# Altium schematic sheet EP40_SEAT.SchDoc
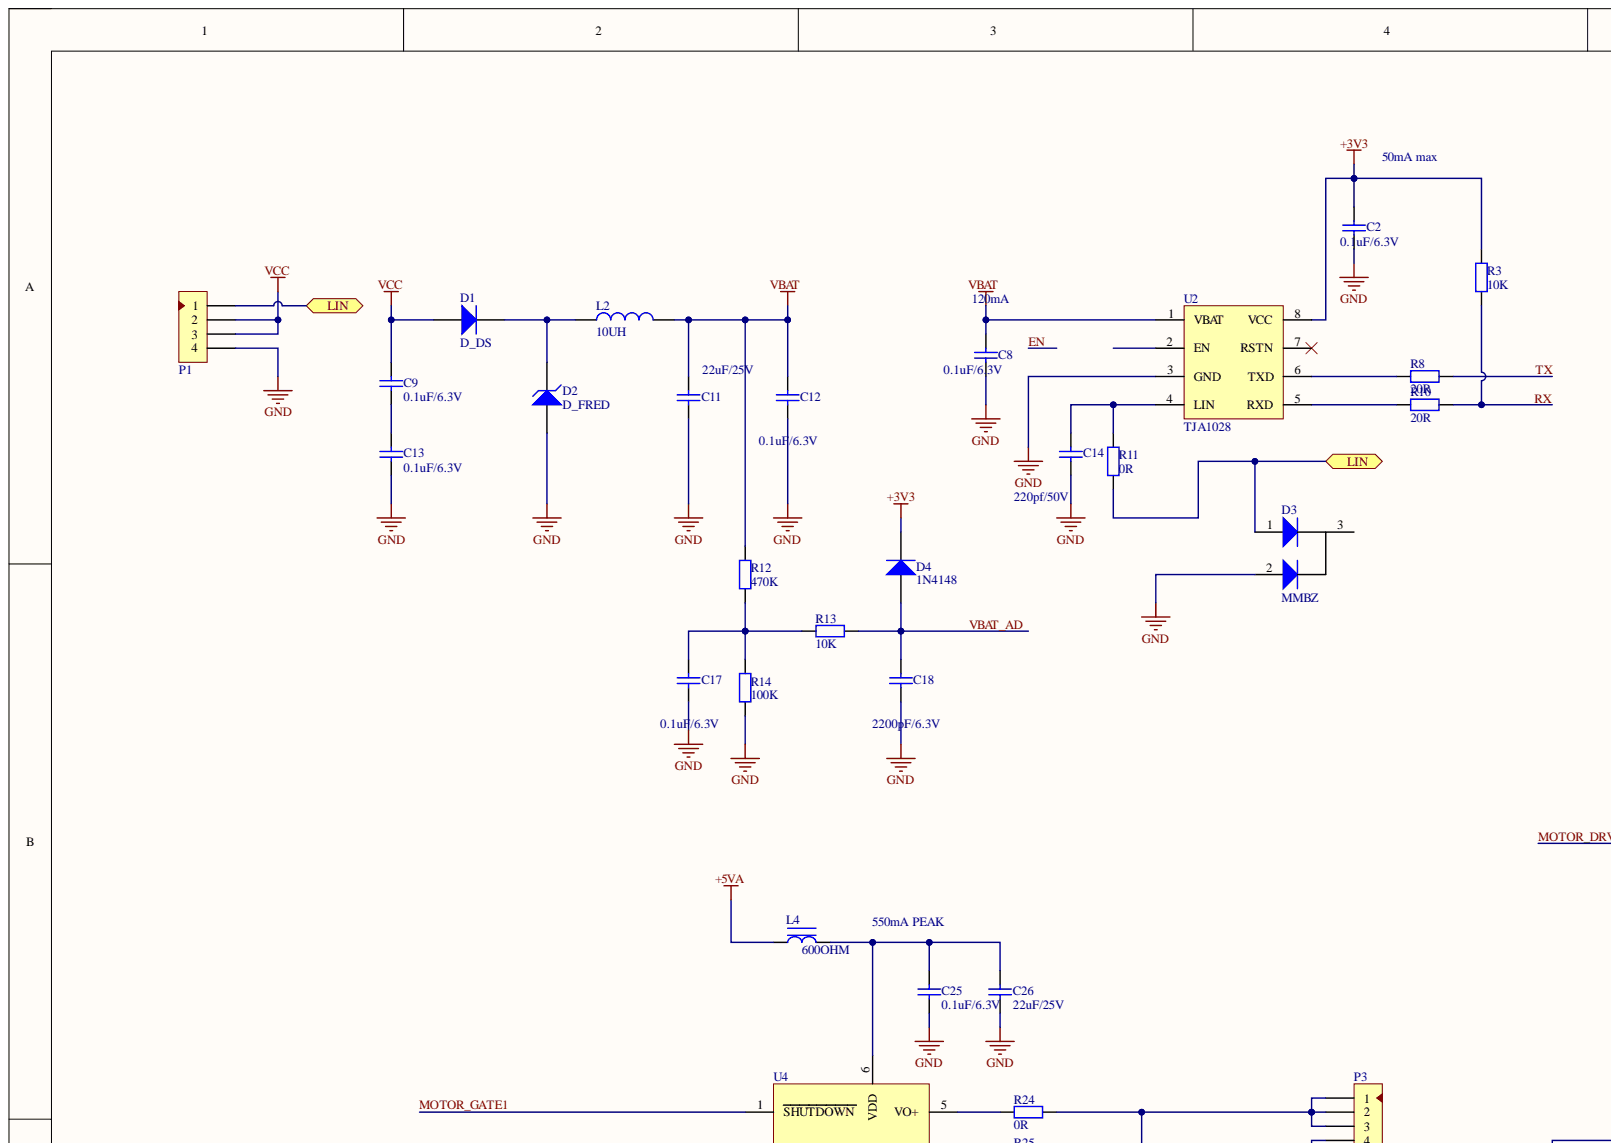
[diagram p0: EP40_SEAT.SchDoc, top left]
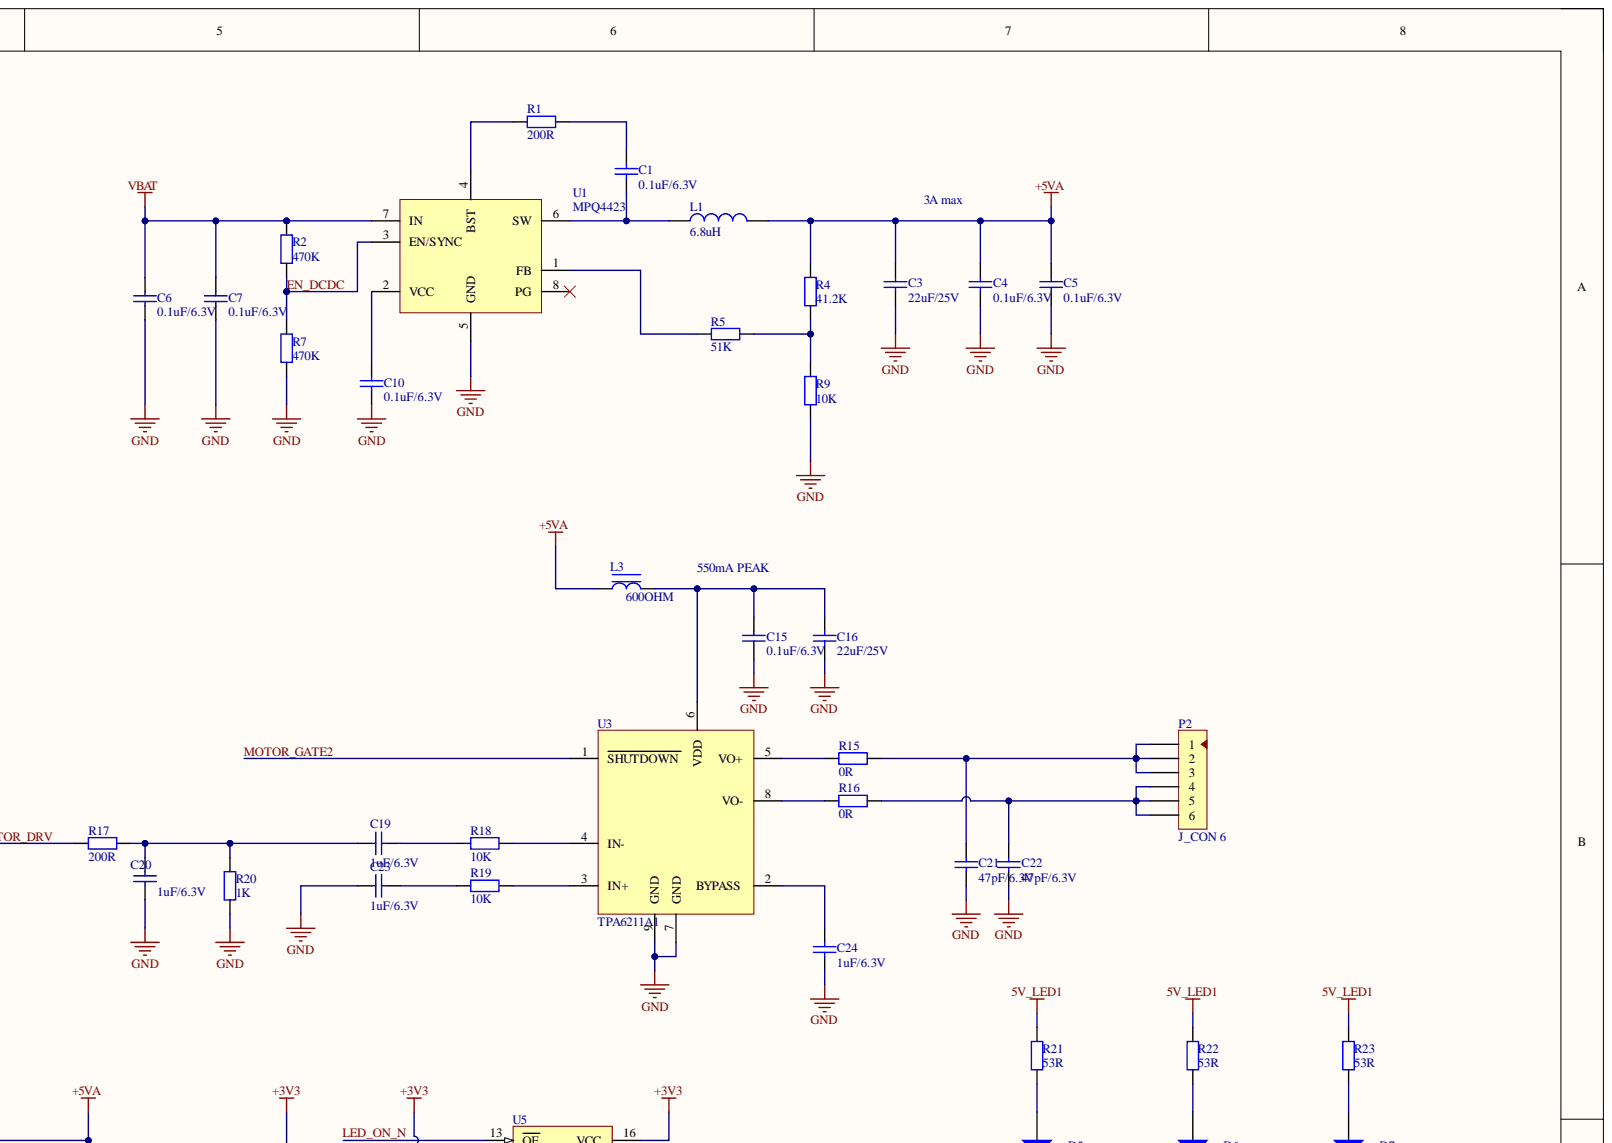
[diagram p1: EP40_SEAT.SchDoc, top right]
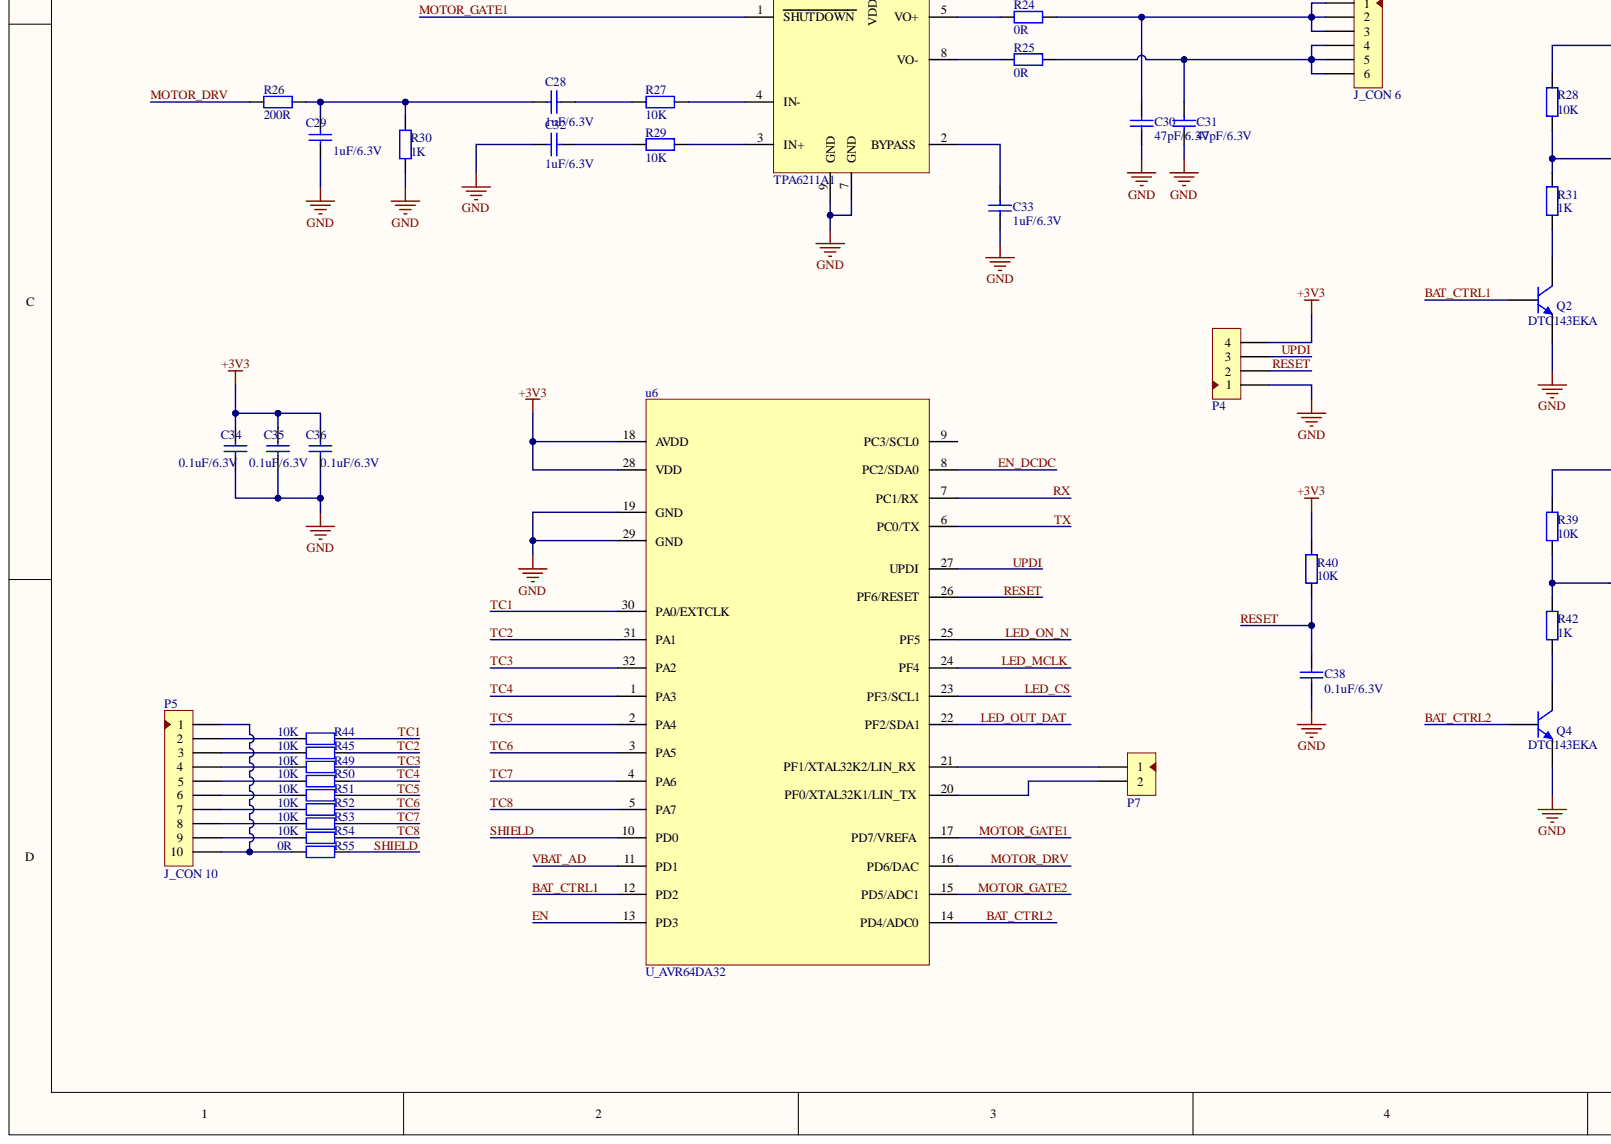
[diagram p2: EP40_SEAT.SchDoc, bottom left]
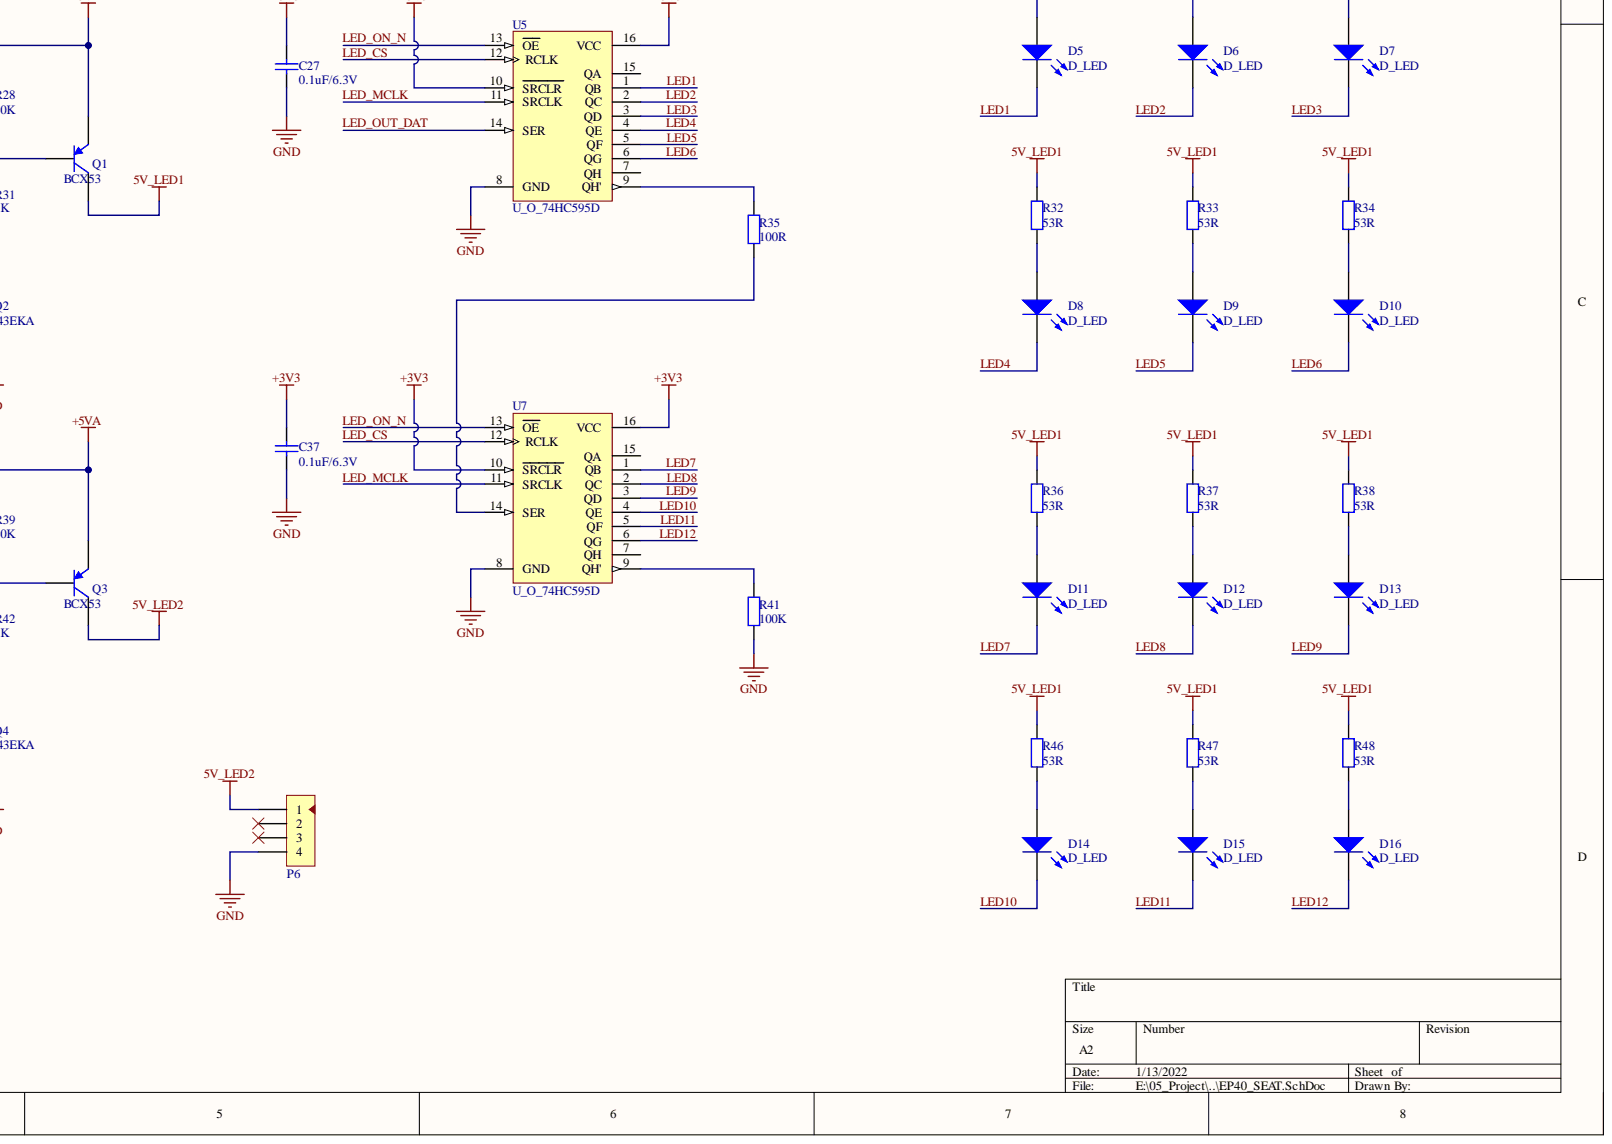
[diagram p3: EP40_SEAT.SchDoc, bottom right]

## Parts
C1  0.1uF/6.3V  [C 0603_N]
C2  0.1uF/6.3V  [C 0603_N]
C3  22uF/25V  [C 1210_N]
C4  0.1uF/6.3V  [C 0603_N]
C5  0.1uF/6.3V  [C 0603_N]
C6  0.1uF/6.3V  [C 0603_N]
C7  0.1uF/6.3V  [C 0603_N]
C8  0.1uF/6.3V  [C 0603_N]
C9  0.1uF/6.3V  [C 0603_N]
C10  0.1uF/6.3V  [C 0603_N]
C11  22uF/25V  [C 1210_N]
C12  0.1uF/6.3V  [C 0603_N]
C13  0.1uF/6.3V  [C 0603_N]
C14  220pf/50V  [C 0603_N]
C15  0.1uF/6.3V  [C 0603_N]
C16  22uF/25V  [C 1206_N]
C17  0.1uF/6.3V  [C 0603_N]
C18  2200pF/6.3V  [C 0603_N]
C19  1uF/6.3V  [C 0603_N]
C20  1uF/6.3V  [C 0603_N]
C21  47pF/6.3V  [C 0603_N]
C22  47pF/6.3V  [C 0603_N]
C23  1uF/6.3V  [C 0603_N]
C24  1uF/6.3V  [C 0603_N]
C25  0.1uF/6.3V  [C 0603_N]
C26  22uF/25V  [C 1206_N]
C27  0.1uF/6.3V  [C 0603_N]
C28  1uF/6.3V  [C 0603_N]
C29  1uF/6.3V  [C 0603_N]
C30  47pF/6.3V  [C 0603_N]
C31  47pF/6.3V  [C 0603_N]
C32  1uF/6.3V  [C 0603_N]
C33  1uF/6.3V  [C 0603_N]
C34  0.1uF/6.3V  [C 0603_N]
C35  0.1uF/6.3V  [C 0603_N]
C36  0.1uF/6.3V  [C 0603_N]
C37  0.1uF/6.3V  [C 0603_N]
C38  0.1uF/6.3V  [C 0603_N]
D1  D_DS  [D SMA(DO-214AC)]
D2  D_FRED  [D SMC(DO-214AB)]
D3  MMBZ  [SOT23-3N]
D4  1N4148  [D SOD-123]
D5  D_LED  [D LED-2214]
D6  D_LED  [D LED-2214]
D7  D_LED  [D LED-2214]
D8  D_LED  [D LED-2214]
D9  D_LED  [D LED-2214]
D10  D_LED  [D LED-2214]
D11  D_LED  [D LED-2214]
D12  D_LED  [D LED-2214]
D13  D_LED  [D LED-2214]
D14  D_LED  [D LED-2214]
D15  D_LED  [D LED-2214]
D16  D_LED  [D LED-2214]
L1  6.8uH  [L NR4030]
L2  10UH  [L-SMD-0630]
L3  600OHM  [L 0805]
L4  600OHM  [L 0805]
P1  J_CON 4  [HDR2-LI-4P]  (value not drawn)
P2  J_CON 6  [FPC0.5 2H-WS-6P]
P3  J_CON 6  [FPC0.5 2H-WS-6P]
P4  J_CON 4  [HDR2.54-LI-4P]  (value not drawn)
P5  J_CON 10  [FPC1.0-2H-WS-10P]
P6  J_CON 4  [HDR2.54-LI-4P]  (value not drawn)
P7  J_CON 2  [HDR2.54-LI-2P]  (value not drawn)
Q1  BCX53  [SOT89_N]
Q2  DTC143EKA  [SOT23-3L]
Q3  BCX53  [SOT89_N]
Q4  DTC143EKA  [SOT23-3L]
R1  200R  [R 0603_N]
R2  470K  [R 0603_N]
R3  10K  [R 0603_N]
R4  41.2K  [R 0603_N]
R5  51K  [R 0603_N]
R7  470K  [R 0603_N]
R8  20R  [R 0603_N]
R9  10K  [R 0603_N]
R10  20R  [R 0603_N]
R11  0R  [R 0603_N]
R12  470K  [R 0603_N]
R13  10K  [R 0603_N]
R14  100K  [R 0603_N]
R15  0R  [R 0603_N]
R16  0R  [R 0603_N]
R17  200R  [R 0603_N]
R18  10K  [R 0603_N]
R19  10K  [R 0603_N]
R20  1K  [R 0603_N]
R21  53R  [R 0603_N]
R22  53R  [R 0603_N]
R23  53R  [R 0603_N]
R24  0R  [R 0603_N]
R25  0R  [R 0603_N]
R26  200R  [R 0603_N]
R27  10K  [R 0603_N]
R28  10K  [R 0603_N]
R29  10K  [R 0603_N]
R30  1K  [R 0603_N]
R31  1K  [R 0603_N]
R32  53R  [R 0603_N]
R33  53R  [R 0603_N]
R34  53R  [R 0603_N]
R35  100R  [R 0603_N]
R36  53R  [R 0603_N]
R37  53R  [R 0603_N]
R38  53R  [R 0603_N]
R39  10K  [R 0603_N]
R40  10K  [R 0603_N]
R41  100K  [R 0603_N]
R42  1K  [R 0603_N]
R44  10K  [R 0402_N]
R45  10K  [R 0402_N]
R46  53R  [R 0603_N]
R47  53R  [R 0603_N]
R48  53R  [R 0603_N]
R49  10K  [R 0402_N]
R50  10K  [R 0402_N]
R51  10K  [R 0402_N]
R52  10K  [R 0402_N]
R53  10K  [R 0402_N]
R54  10K  [R 0402_N]
R55  0R  [R 0402_N]
U1  MPQ4423  [QFN8 3X3 - 4423]
U2  TJA1028  [QFN8 3X3]
U3  TPA6211A1  [MSOP8_N - EP]
U4  TPA6211A1  [MSOP8_N - EP]
U5  U_O_74HC595D  [SOP16_N]
u6  U_AVR64DA32  [LQFP32 7X7_N]
U7  U_O_74HC595D  [SOP16_N]

## Ports
LIN

## Nets
5V_LED1: C3.2 C4.2 C5.2 L1.2 L3.1 L4.1 Q1.2 Q1.3 Q3.3 R4.2 R21.2 R22.2 R23.2 R28.2 R32.2 R33.2 R34.2 R36.2 R37.2 R38.2 R39.2 R46.2 R47.2 R48.2
5V_LED2: P6.1 Q3.2
+3V3: C2.2 C27.2 C34.2 C35.2 C36.2 C37.2 D4.2 P4.4 R3.1 R40.2 U2.8(VCC) U5.10(SRCLR) U5.16(VCC) u6.18(AVDD) u6.28(VDD) U7.10(SRCLR) U7.16(VCC)
GND: C2.1 C3.1 C4.1 C5.1 C6.1 C7.1 C8.1 C10.1 C11.1 C12.1 C13.1 C14.1 C15.1 C16.1 C17.1 C18.1 C20.2 C21.2 C22.2 C23.2 C24.2 C25.1 C26.1 C27.1 C29.2 C30.2 C31.2 C32.2 C33.2 C34.1 C35.1 C36.1 C37.1 C38.1 D2.1 D3.2 P1.4 P4.1 P6.4 Q2.2 Q4.2 R7.1 R9.1 R14.1 R20.2 R30.2 R41.1 U1.5(GND) U2.3(GND) U3.7(GND) U3.9(GND) U4.7(GND) U4.9(GND) U5.8(GND) u6.19(GND) u6.29(GND) U7.8(GND)
VBAT: C6.2 C7.2 C8.2 C11.2 C12.2 L2.2 R2.2 R12.2 U1.7(IN) U2.1(VBAT)
VCC: C9.2 D1.1 P1.2 P1.3
BAT_CTRL1: Q2.1 u6.12(PD2)
BAT_CTRL2: Q4.1 u6.14(PD4/ADC0)
EN: u6.13(PD3)
EN_DCDC: R2.1 R7.2 U1.3(EN/SYNC) u6.8(PC2/SDA0)
LED1: D5.2 U5.1(QB)
LED2: D6.2 U5.2(QC)
LED3: D7.2 U5.3(QD)
LED4: D8.2 U5.4(QE)
LED5: D9.2 U5.5(QF)
LED6: D10.2 U5.6(QG)
LED7: D11.2 U7.1(QB)
LED8: D12.2 U7.2(QC)
LED9: D13.2 U7.3(QD)
LED10: D14.2 U7.4(QE)
LED11: D15.2 U7.5(QF)
LED12: D16.2 U7.6(QG)
LED_CS: U5.12(RCLK) u6.23(PF3/SCL1) U7.12(RCLK)
LED_MCLK: U5.11(SRCLK) u6.24(PF4) U7.11(SRCLK)
LED_ON_N: U5.13(OE) u6.25(PF5) U7.13(OE)
LED_OUT_DAT: U5.14(SER) u6.22(PF2/SDA1)
LIN: D3.1 P1.1 R11.1 ; port LIN
MOTOR_DRV: R17.2 R26.2 u6.16(PD6/DAC)
MOTOR_GATE1: U4.1(SHUTDOWN) u6.17(PD7/VREFA)
MOTOR_GATE2: U3.1(SHUTDOWN) u6.15(PD5/ADC1)
RESET: C38.2 P4.2 R40.1 u6.26(PF6/RESET)
RX: R3.2 R10.1 u6.7(PC1/RX)
SHIELD: R55.1 u6.10(PD0)
TC1: R44.1 u6.30(PA0/EXTCLK)
TC2: R45.1 u6.31(PA1)
TC3: R49.1 u6.32(PA2)
TC4: R50.1 u6.1(PA3)
TC5: R51.1 u6.2(PA4)
TC6: R52.1 u6.3(PA5)
TC7: R53.1 u6.4(PA6)
TC8: R54.1 u6.5(PA7)
TX: R8.1 u6.6(PC0/TX)
UPDI: P4.3 u6.27(UPDI)
VBAT_AD: C18.2 D4.1 R13.1 u6.11(PD1)
NetC1_1: C1.1 L1.1 U1.6(SW)
NetC1_2: C1.2 R1.1
NetC9_1: C9.1 C13.2
NetC10_2: C10.2 U1.2(VCC)
NetC14_2: C14.2 R11.2 U2.4(LIN)
NetC15_2: C15.2 C16.2 L3.2 U3.6(VDD)
NetC17_2: C17.2 R12.1 R13.2 R14.2
NetC19_1: C19.1 R18.2
NetC19_2: C19.2 C20.1 R17.1 R20.1
NetC21_1: C21.1 P2.1 P2.2 P2.3 R15.1
NetC22_1: C22.1 P2.4 P2.5 P2.6 R16.1
NetC23_1: C23.1 R19.2
NetC24_1: C24.1 U3.2(BYPASS)
NetC25_2: C25.2 C26.2 L4.2 U4.6(VDD)
NetC28_1: C28.1 R27.2
NetC28_2: C28.2 C29.1 R26.1 R30.1
NetC30_1: C30.1 P3.1 P3.2 P3.3 R24.1
NetC31_1: C31.1 P3.4 P3.5 P3.6 R25.1
NetC32_1: C32.1 R29.2
NetC33_1: C33.1 U4.2(BYPASS)
NetD1_2: D1.2 D2.2 L2.1
NetD5_1: D5.1 R21.1
NetD6_1: D6.1 R22.1
NetD7_1: D7.1 R23.1
NetD8_1: D8.1 R32.1
NetD9_1: D9.1 R33.1
NetD10_1: D10.1 R34.1
NetD11_1: D11.1 R36.1
NetD12_1: D12.1 R37.1
NetD13_1: D13.1 R38.1
NetD14_1: D14.1 R46.1
NetD15_1: D15.1 R47.1
NetD16_1: D16.1 R48.1
NetP5_1: P5.1 P5.10 R55.2
NetP5_2: P5.2 R44.2
NetP5_3: P5.3 R45.2
NetP5_4: P5.4 R49.2
NetP5_5: P5.5 R50.2
NetP5_6: P5.6 R51.2
NetP5_7: P5.7 R52.2
NetP5_8: P5.8 R53.2
NetP5_9: P5.9 R54.2
NetP7_1: P7.1 u6.21(PF1/XTAL32K2/LIN_RX)
NetP7_2: P7.2 u6.20(PF0/XTAL32K1/LIN_TX)
NetQ1_1: Q1.1 R28.1 R31.2
NetQ2_3: Q2.3 R31.1
NetQ3_1: Q3.1 R39.1 R42.2
NetQ4_3: Q4.3 R42.1
NetR1_2: R1.2 U1.4(BST)
NetR4_1: R4.1 R5.1 R9.2
NetR5_2: R5.2 U1.1(FB)
NetR8_2: R8.2 U2.6(TXD)
NetR10_2: R10.2 U2.5(RXD)
NetR15_2: R15.2 U3.5(VO+)
NetR16_2: R16.2 U3.8(VO-)
NetR18_1: R18.1 U3.4(IN-)
NetR19_1: R19.1 U3.3(IN+)
NetR24_2: R24.2 U4.5(VO+)
NetR25_2: R25.2 U4.8(VO-)
NetR27_1: R27.1 U4.4(IN-)
NetR29_1: R29.1 U4.3(IN+)
NetR35_1: R35.1 U7.14(SER)
NetR35_2: R35.2 U5.9(QH')
NetR41_2: R41.2 U7.9(QH')
NetU1_8: U1.8(PG) u6.9(PC3/SCL0)

Not connected: D3 3; P6 2 3; U2 2(EN) 7(RSTN); U5 7(QH) 15(QA); U7 7(QH) 15(QA)

## Library data of the parts
C1-C38 = C_CAP: 贴片电容; Component Kind: Standard; Library Name: 01_Basic.SchLib
D1 D4 = D_DS: 整流/开关二极管; Component Kind: Standard; Library Name: 01_Basic.SchLib
D2 = D_FRED: 超快恢复二极管; Component Kind: Standard; Library Name: 01_Basic.SchLib
D3 = D_DDA1: 共阴极双开关二极管; Component Kind: Standard; Library Name: 01_Basic.SchLib
D5-D16 = D_LED: 发光二极管; Component Kind: Standard; Library Name: 01_Basic.SchLib
L1 L2 = L_IND: 电感; Component Kind: Standard; Library Name: 01_Basic.SchLib
L3 L4 = L_IRON: 功率电感; Component Kind: Standard; Library Name: 01_Basic.SchLib
P1 P4 P6 = J_CON 4: 接插件; Component Kind: Standard; LatestRevisionDate: 17-Jul-2002; LatestRevisionNote: Re-released for DXP Platform.; Library Name: 02_Ports.SchLib; Publisher: Altium Limited
P2 P3 = J_CON 6: 接插件; Component Kind: Standard; LatestRevisionDate: 17-Jul-2002; LatestRevisionNote: Re-released for DXP Platform.; Library Name: 02_Ports.SchLib; Publisher: Altium Limited
P5 = J_CON 10: 接插件; Component Kind: Standard; LatestRevisionDate: 17-Jul-2002; LatestRevisionNote: Re-released for DXP Platform.; Library Name: 02_Ports.SchLib; Publisher: Altium Limited
P7 = J_CON 2: 接插件; Component Kind: Standard; LatestRevisionDate: 17-Jul-2002; LatestRevisionNote: Re-released for DXP Platform.; Library Name: 02_Ports.SchLib; Publisher: Altium Limited
Q1 Q3 = Q_PNP: PNP型三极管; Component Kind: Standard; Library Name: 01_Basic.SchLib
Q2 Q4 = Q_NPN: NPN型三极管; Component Kind: Standard; Library Name: 01_Basic.SchLib
R1-R5 R7-R42 R44-R55 = R_RES: 贴片电阻; Component Kind: Standard; Library Name: 01_Basic.SchLib
U3 U4 = U_TPA6211: Component Kind: Standard; Library Name: 03_IC.SchLib
U5 U7 = U_O_74HC595D: 74系列逻辑芯片; Component Kind: Standard; Library Name: 03_IC.SchLib
U1 = MPQ4423: Component Kind: Standard; Library Name: 03_IC.SchLib
U2 = TJA1028: Component Kind: Standard; Library Name: 03_IC.SchLib
u6 = U_AVR64DA32: Component Kind: Standard; Library Name: 03_IC.SchLib
